# Altium schematic sheet Power Supply2.SchDoc("Power Supply2")
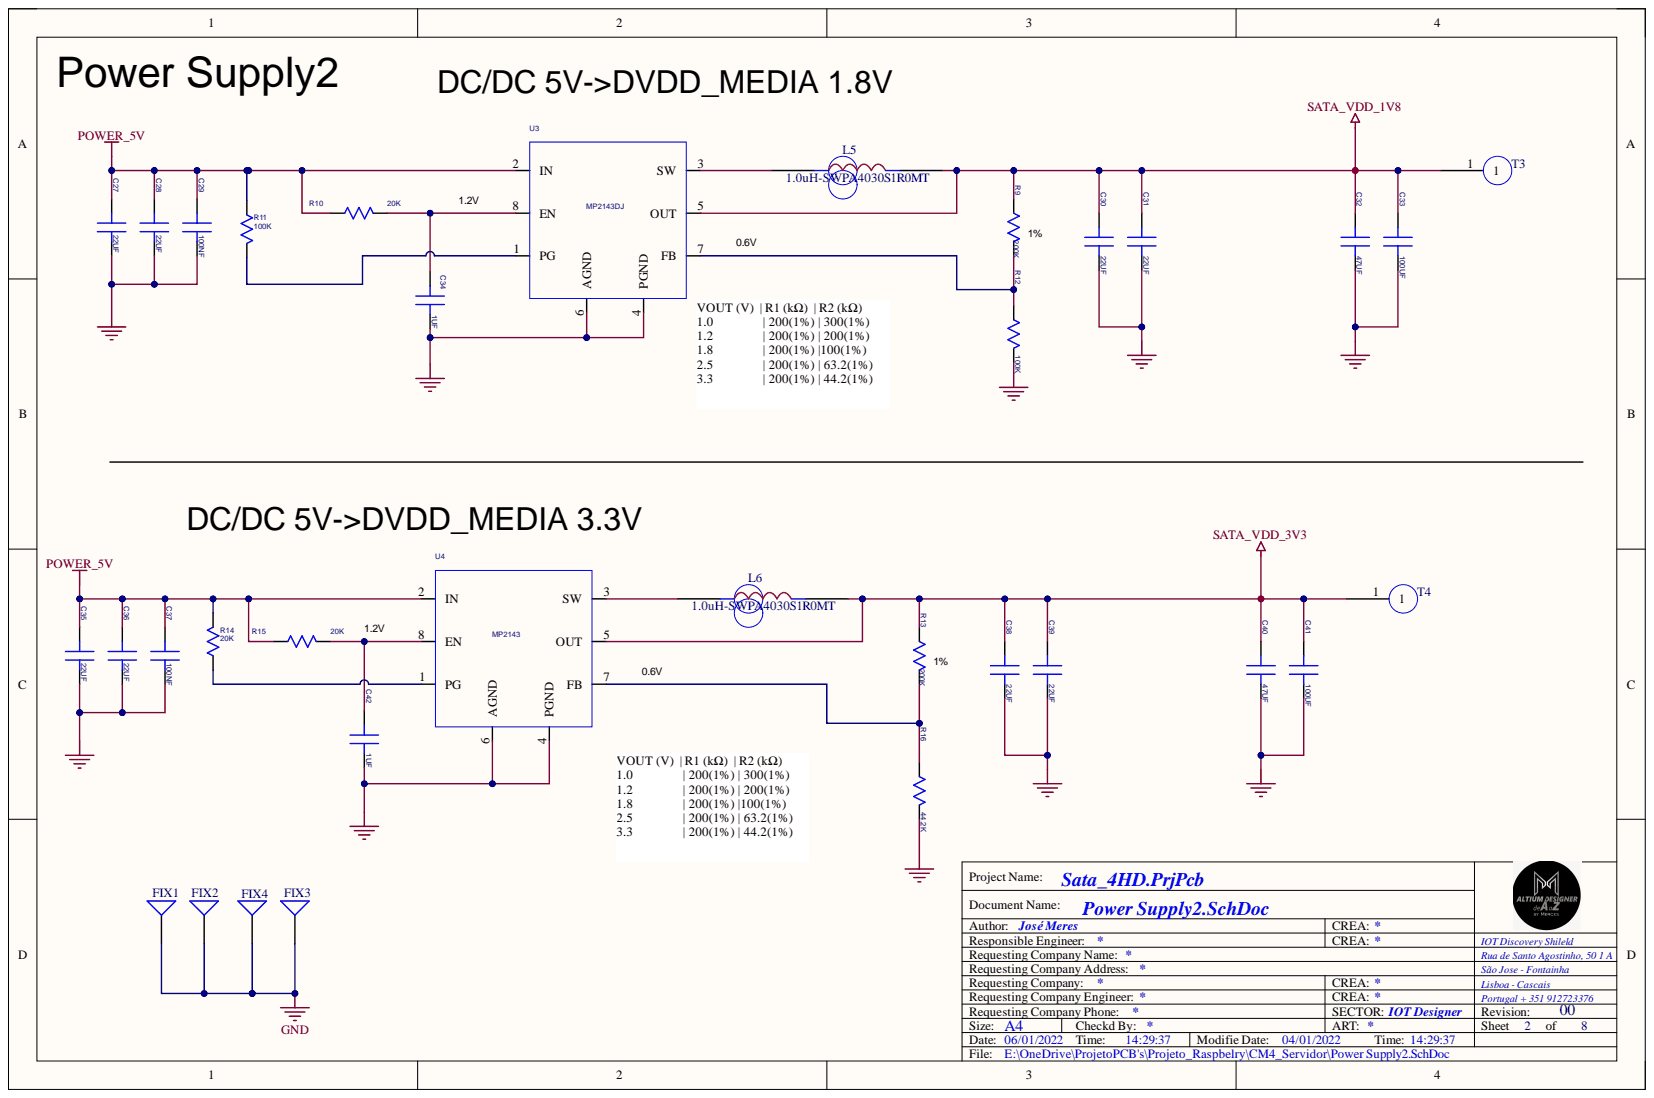
[diagram p0: Power Supply2.SchDoc]

## Parts
C27  22UF  [C0805-ROUND]
C28  22UF  [C0805-ROUND]
C29  100NF  [C0805-ROUND]
C30  22UF  [C0805-ROUND]
C31  22UF  [C0805-ROUND]
C32  47UF  [C0805-ROUND]
C33  100UF  [C0805-ROUND]
C34  1UF  [C0402-ROUND]
C35  22UF  [C0805-ROUND]
C36  22UF  [C0805-ROUND]
C37  100NF  [C0805-ROUND]
C38  22UF  [C0805-ROUND]
C39  22UF  [C0805-ROUND]
C40  47UF  [C0805-ROUND]
C41  100UF  [C0805-ROUND]
C42  1UF  [C0402-ROUND]
FIX1  Fix  [Fix_6X3,5]  (value not drawn)
FIX2  Fix  [Fix_6X3,5]  (value not drawn)
FIX3  Fix  [Fix_6X3,5]  (value not drawn)
FIX4  Fix  [Fix_6X3,5]  (value not drawn)
L5  1.0uH-SWPA4030S1R0MT  [NRS3015T2R2MNGHV]
L6  1.0uH-SWPA4030S1R0MT  [NRS3015T2R2MNGHV]
R9  200K  [R0402-ROUND]
R10  20K  [R0402-ROUND]
R11  100K  [R0402-ROUND]
R12  100K  [R0402-ROUND]
R13  200K  [R0402-ROUND]
R14  20K  [R0402-ROUND]
R15  20K  [R0402-ROUND]
R16  44.2K  [R0402-ROUND]
T3  TEST  [TEST_40]  (value not drawn)
T4  TEST  [TEST_40]  (value not drawn)
U3  MP2143  DEVICE: MP2143DJ  [SOT23-8]
U4  MP2143  [SOT23-8]

## Nets
GND: C27.2 C28.2 C29.2 C30.2 C31.2 C32.2 C33.2 C34.2 C35.2 C36.2 C37.2 C38.2 C39.2 C40.2 C41.2 C42.2 FIX1.FIX FIX2.FIX FIX3.FIX FIX4.FIX R12.2 R16.2 U3.4(PGND) U3.6(AGND) U4.4(PGND) U4.6(AGND)
POWER_5V: C27.1 C28.1 C29.1 C35.1 C36.1 C37.1 R10.1 R11.1 R14.2 R15.1 U3.2(IN) U4.2(IN)
SATA_VDD_1V8: C30.1 C31.1 C32.1 C33.1 L5.2 R9.1 T3.1 U3.5(OUT)
SATA_VDD_3V3: C38.1 C39.1 C40.1 C41.1 L6.2 R13.1 T4.1 U4.5(OUT)
NetC34_1: C34.1 R10.2 U3.8(EN)
NetC42_1: C42.1 R15.2 U4.8(EN)
NetL5_1: L5.1 U3.3(SW)
NetL6_1: L6.1 U4.3(SW)
NetR9_2: R9.2 R12.1 U3.7(FB)
NetR11_2: R11.2 U3.1(PG)
NetR13_2: R13.2 R16.1 U4.7(FB)
NetR14_1: R14.1 U4.1(PG)

## Library data of the parts
C27 C28 C35 C36 = C_0_Dup3: PARTS: 1; %182%%238%%182%%168%%185%%164%%215%%247%%181%%231%%209%%185%(V): 6.3; %195%%232%%202%%246%: SMD陶瓷电容-6.3V-22000NF-+/-20%-X5R-1206-BT,TS16949; %208%%161%%192%%224%%195%%251%%179%%198%: SMD陶瓷电容; CODE: 8070630; COMPONENT_HIGH_MAX: 1.9MM; DEVICE: GRM31CR60J226ME19L; LEVEL: STD; OriginalSymbolOrigin: (0,30); PCB Footprint: SC0603; PINSWAP: (A,B); PKG_TYPE: SC0805; Component Kind: Standard
C29 C37 = C_0_Dup3: PARTS: 1; %182%%238%%182%%168%%185%%164%%215%%247%%181%%231%%209%%185%(V): 16; %195%%232%%202%%246%: SMD陶瓷电容-16V-100NF-+/-10%-X7R-0402-BT; %208%%161%%192%%224%%195%%251%%179%%198%: SMD陶瓷电容; CODE: 8070614; COMPONENT_HIGH_MAX: 0.55MM; DEVICE: RMEMK105BJ104KV_FR; LEVEL: STD; OriginalSymbolOrigin: (0,30); PCB Footprint: SC0402; PINSWAP: (A,B); Component Kind: Standard; PKG_TYPE: SC0402
C30 C38 = C_0_Dup3: PARTS: 1; %182%%238%%182%%168%%185%%164%%215%%247%%181%%231%%209%%185%(V): 6.3; %195%%232%%202%%246%: SMD陶瓷电容-6.3V-22000NF-+/-20%-X5R-1206-BT,TS16949; %208%%161%%192%%224%%195%%251%%179%%198%: SMD陶瓷电容; CODE: 8070630; COMPONENT_HIGH_MAX: 1.9MM; DEVICE: GRM31CR60J226ME19L; LEVEL: STD; OriginalSymbolOrigin: (0,30); PCB Footprint: SC0805; PINSWAP: (A,B); Component Kind: Standard; PKG_TYPE: SC0805
C31 C39 = C_0_Dup3: PARTS: 1; %182%%238%%182%%168%%185%%164%%215%%247%%181%%231%%209%%185%(V): 6.3; %195%%232%%202%%246%: SMD陶瓷电容-6.3V-22000NF-+/-20%-X5R-1206-BT,TS16949; %208%%161%%192%%224%%195%%251%%179%%198%: SMD陶瓷电容; CODE: 8070630; COMPONENT_HIGH_MAX: 1.9MM; DEVICE: GRM31CR60J226ME19L; LEVEL: STD; OriginalSymbolOrigin: (0,30); PCB Footprint: SC0805; PINSWAP: (A,B); VARIANT_ID: $37I1947; Component Kind: Standard; PKG_TYPE: SC0805
C32 C40 = C_0_Dup3: PARTS: 1; %182%%238%%182%%168%%185%%164%%215%%247%%181%%231%%209%%185%(V): 6.3; %195%%232%%202%%246%: SMD陶瓷电容-6.3V-47000nF-+/-20%-X6S-1206-供电专用; %208%%161%%192%%224%%195%%251%%179%%198%: SMD陶瓷电容; CODE: 08071639; COMPONENT_HIGH_MAX: 1.9MM; DEVICE: GRM31CR60J226ME19L; LEVEL: STD; OriginalSymbolOrigin: (0,30); PCB Footprint: SC0805; PINSWAP: (A,B); Component Kind: Standard; PKG_TYPE: SC0805
C33 C41 = C_0_Dup3: PARTS: 1; %182%%238%%182%%168%%185%%164%%215%%247%%181%%231%%209%%185%(V): 6.3; %195%%232%%202%%246%: SMD陶瓷电容-4V-100000nF-+/-20%-X6T-1206; %208%%161%%192%%224%%195%%251%%179%%198%: SMD陶瓷电容; CODE: 8120045; COMPONENT_HIGH_MAX: 1.9MM; DEVICE: GRM31CR60J226ME19L; LEVEL: STD; OriginalSymbolOrigin: (0,30); PCB Footprint: SC1206; PINSWAP: (A,B); VARIANT_ID: $37I2400; Component Kind: Standard; PKG_TYPE: SC1206
C34 C42 = C_0_Dup3: PARTS: 1; %182%%238%%182%%168%%185%%164%%215%%247%%181%%231%%209%%185%(V): 10; %195%%232%%202%%246%: SMD陶瓷电容-10V-1000nF-+/-10%-X5R-0402-BT,TS16949; %208%%161%%192%%224%%195%%251%%179%%198%: SMD陶瓷电容; CODE: 8070612; COMPONENT_HIGH_MAX: 0.55mm; DEVICE: CV05X5R105K10AH; LEVEL: STD; OriginalSymbolOrigin: (0,30); PCB Footprint: SC0402; PINSWAP: (A,B); VARIANT_ID: $38I2629; Component Kind: Standard; PKG_TYPE: SC0402
FIX1-FIX4 = Fix: Generic Antenna; Component Kind: Standard; LatestRevisionDate: 17-Jul-2002; LatestRevisionNote: Re-released for DXP Platform.; PackageReference: PIN1; Published: 24-Mar-1999; Library Name: Merces_Library.SchLib; Publisher: Altium Limited
L5 L6 = SWPA4030S: 贴片箌率电感; SIGNAL_MODEL: DEFAULT_INDUCTOR_1e+010nH_1_2; Component Kind: Standard; PCB Footprint: swpa4030s
R9 R13 = R_0_Dup2: PARTS: 1; %182%%238%%182%%168%%185%%166%%194%%202%(W): 0.1; %195%%232%%202%%246%: 片式厚膜电阻器-0.1W-12700OHM-+/-1%-0603--供电专用-07090924-供电专用; %208%%161%%192%%224%%195%%251%%179%%198%: 片式厚膜电阻器; %215%%232%%214%%181%%212%%202%%208%%237%%198%%171%%178%%238%: +/-1%; CODE: 7091203; COMPONENT_HIGH_MAX: 0.45MM; DEVICE: RC0603FR_0712K7L; LEVEL: STD; OriginalSymbolOrigin: (10,20); PCB Footprint: SR0402; PINSWAP: (A,B); PKG_TYPE: SR0603; Component Kind: Standard
R10 R11 R14 R15 = R_0_Dup2: PARTS: 1; %195%%232%%202%%246%: 片式厚膜电阻器-0.0625W-20000OHM-+/-1%-0402; %208%%161%%192%%224%%195%%251%%179%%198%: 片式厚膜电阻器; CODE: 7091374; COMPONENT_HIGH_MAX: 999999MM; DEVICE: RC_02W2002FT; LEVEL: STD; OriginalSymbolOrigin: (10,20); PCB Footprint: SR0402; PINSWAP: (A,B); Component Kind: Standard; PKG_TYPE: SR0402
R12 R16 = R_0_Dup2: PARTS: 1; %195%%232%%202%%246%: 片式厚膜电阻器-0.1W-33200ohm-+/-1%-0603; %208%%161%%192%%224%%195%%251%%179%%198%: 片式厚膜电阻器; CODE: 7090937; COMPONENT_HIGH_MAX: 0.55MM; DEVICE: RC0603FR_0733K2; LEVEL: STD; OriginalSymbolOrigin: (10,20); PCB Footprint: SR0402; PINSWAP: (A,B); PKG_TYPE: SR0603; Component Kind: Standard
T3 T4 = TEST: PCB Footprint: tp_40; Component Kind: Standard
U3 U4 = MP2143_0: PARTS: 1; CODE: 99054LFT; LEVEL: STD; OriginalSymbolOrigin: (20,130); PCB Footprint: TSOT23-8; Component Kind: Standard; PKG_TYPE: TSOT23-8
  U4: DEVICE: MP2143
